# Altium schematic sheet PF_Sch.SchDoc
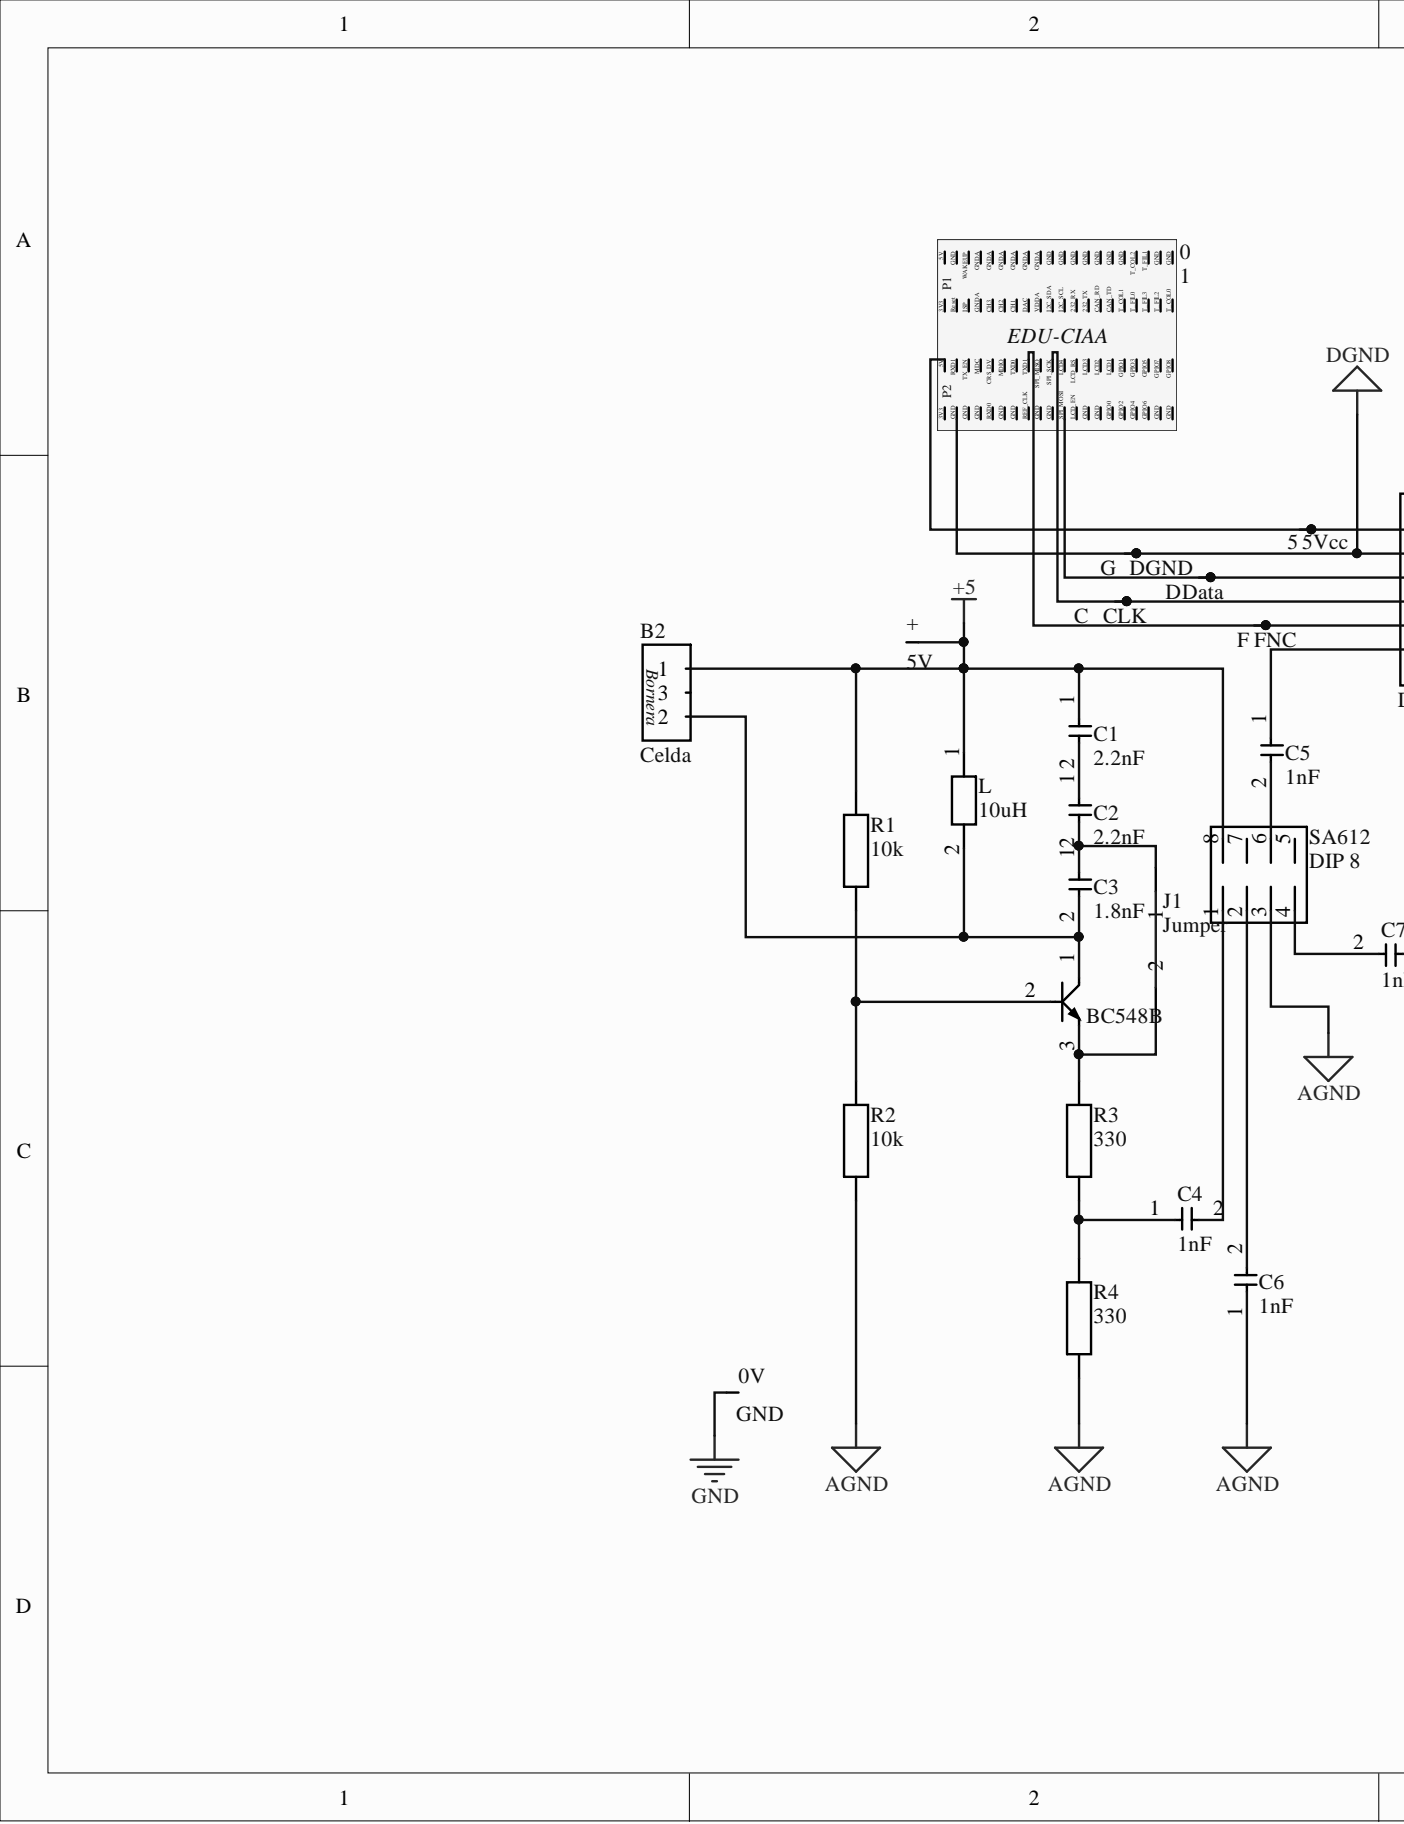
[diagram p0: PF_Sch.SchDoc, left]
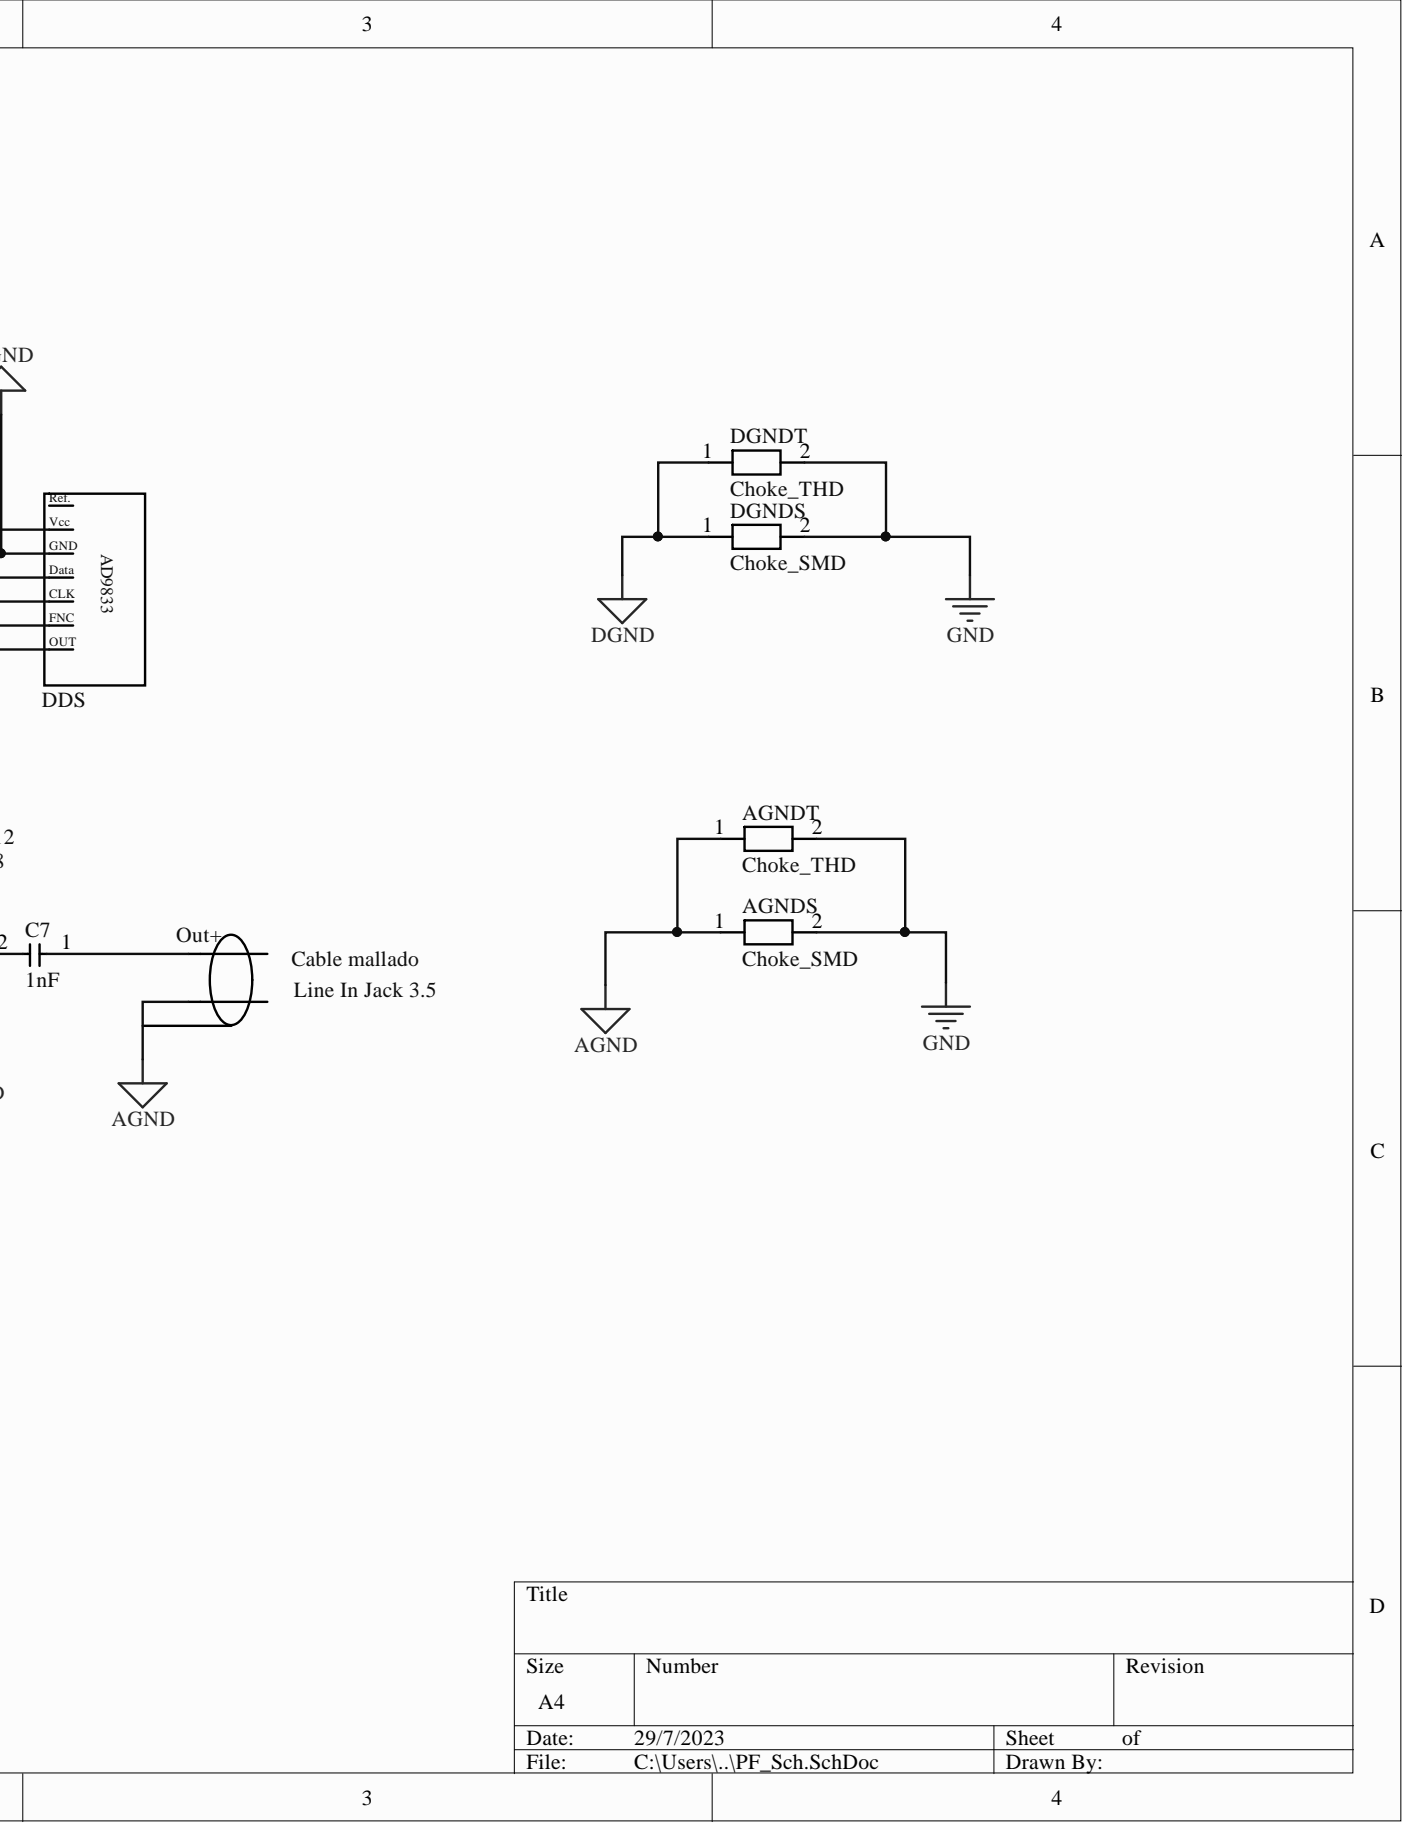
[diagram p1: PF_Sch.SchDoc, right]

## Parts
0  1  [EDU-CIAA]
0V  GND  [Cable]
1  BC548B  [BC548B]
5  5Vcc  [Cable]
+  5V  [Cable]
AGNDS  Choke_SMD  [0805]
AGNDT  Choke_THD  [Choke_THD]
B2  Celda  [Bornera]
C  CLK  [Cable]
C1  2.2nF  [Cap]
C2  2.2nF  [Cap]
C3  1.8nF  [Cap]
C4  1nF  [Cap]
C5  1nF  [Cap]
C6  1nF  [Cap]
C7  1nF  [Cap]
D  Data  [Cable]
DDS  DDS  [AD9833]
DGNDS  Choke_SMD  [0805]
DGNDT  Choke_THD  [Choke_THD]
F  FNC  [Cable]
G  DGND  [Cable]
J1  Jumper  [Jumper]
L  10uH  [Induc]
Out+  Cable  [Cable]
Out-  Cable  [Cable]  (value not drawn)
R1  10k  [R_8mm_0.25W]
R2  10k  [R_8mm_0.25W]
R3  330  [R_8mm_0.25W]
R4  330  [R_8mm_0.25W]
SA612  DIP 8  [Socket DIP 8]

## Nets
+5: +.1 B2.1 C1.1 L.1 R1.2 SA612.8
AGND: AGNDS.1 AGNDT.1 C6.1 Out-.1 R2.1 R4.1 SA612.3
DGND: 0.2_3 DDS.3 DGNDS.1 DGNDT.1 G.1
GND: 0V.1 AGNDS.2 AGNDT.2 DGNDS.2 DGNDT.2
Net0_2_2: 0.2_2 5.1 DDS.2
Net0_2_16: 0.2_16 DDS.6 F.1
Net0_2_20: 0.2_20 C.1 DDS.5
Net0_2_21: 0.2_21 D.1 DDS.4
Net1_1: 1.1 B2.2 C3.2 L.2
Net1_2: 1.2 R1.1 R2.2
Net1_3: 1.3 J1.2 R3.2
NetC1_2: C1.2 C2.1
NetC2_2: C2.2 C3.1 J1.1
NetC4_1: C4.1 R3.1 R4.2
NetC4_2: C4.2 SA612.1
NetC5_1: C5.1 DDS.7
NetC5_2: C5.2 SA612.6
NetC6_2: C6.2 SA612.2
NetC7_1: C7.1 Out+.1
NetC7_2: C7.2 SA612.4

Not connected: 0 1 2(P1) 2_1(P2) 2_4 2_5 2_6 2_7 2_8 2_9 2_10 2_11 2_12 2_13 2_14 2_15 2_17(SPI_MISO) 2_18 2_19 2_22 2_23 2_24 2_25 2_26 2_27 2_28 2_29 2_30 2_31 2_32 2_33 2_34 2_35 2_36 2_37 2_38 2_39 2_40 3 4 5 6 7 8 9 10 11 12 13 14 15 16 17 18 19 20 21 22 23 24 25 26 27 28 29 30 31 32 33 34 35 36 37 38 39 40; B2 3; DDS 1; SA612 5 7

## Library data of the parts
0 = EDU-CIAA: Component Kind: Standard; Library Name: PF_SchLib.SchLib
0V 5 + C D F G = Cable: Component Kind: Standard; Library Name: PF_SchLib.SchLib
B2 = Bornera: Component Kind: Standard; Library Name: PF_SchLib.SchLib
C1-C7 = Capa: Component Kind: Standard; Library Name: PF_SchLib.SchLib
DDS = AD9833: Component Kind: Standard; Library Name: PF_SchLib.SchLib
L = Induc: Component Kind: Standard; Library Name: PF_SchLib.SchLib
R1-R4 = R_0.25W: Component Kind: Standard; Library Name: PF_SchLib.SchLib
SA612 = Socket DIP 8: Component Kind: Standard; Library Name: PF_SchLib.SchLib
1 = BC548B: Component Kind: Standard; Library Name: PF_SchLib.SchLib
AGNDS DGNDS = Choke_SMD: Component Kind: Standard; Library Name: PF_SchLib.SchLib
AGNDT DGNDT = Choke_THD: Component Kind: Standard; Library Name: PF_SchLib.SchLib
J1 = Jumper: Component Kind: Standard; Library Name: PF_SchLib.SchLib
Out+ Out- = Cable: Component Kind: Standard; Library Name: PF_SchLib.SchLib
